# Altium schematic sheet MAIN.SchDoc
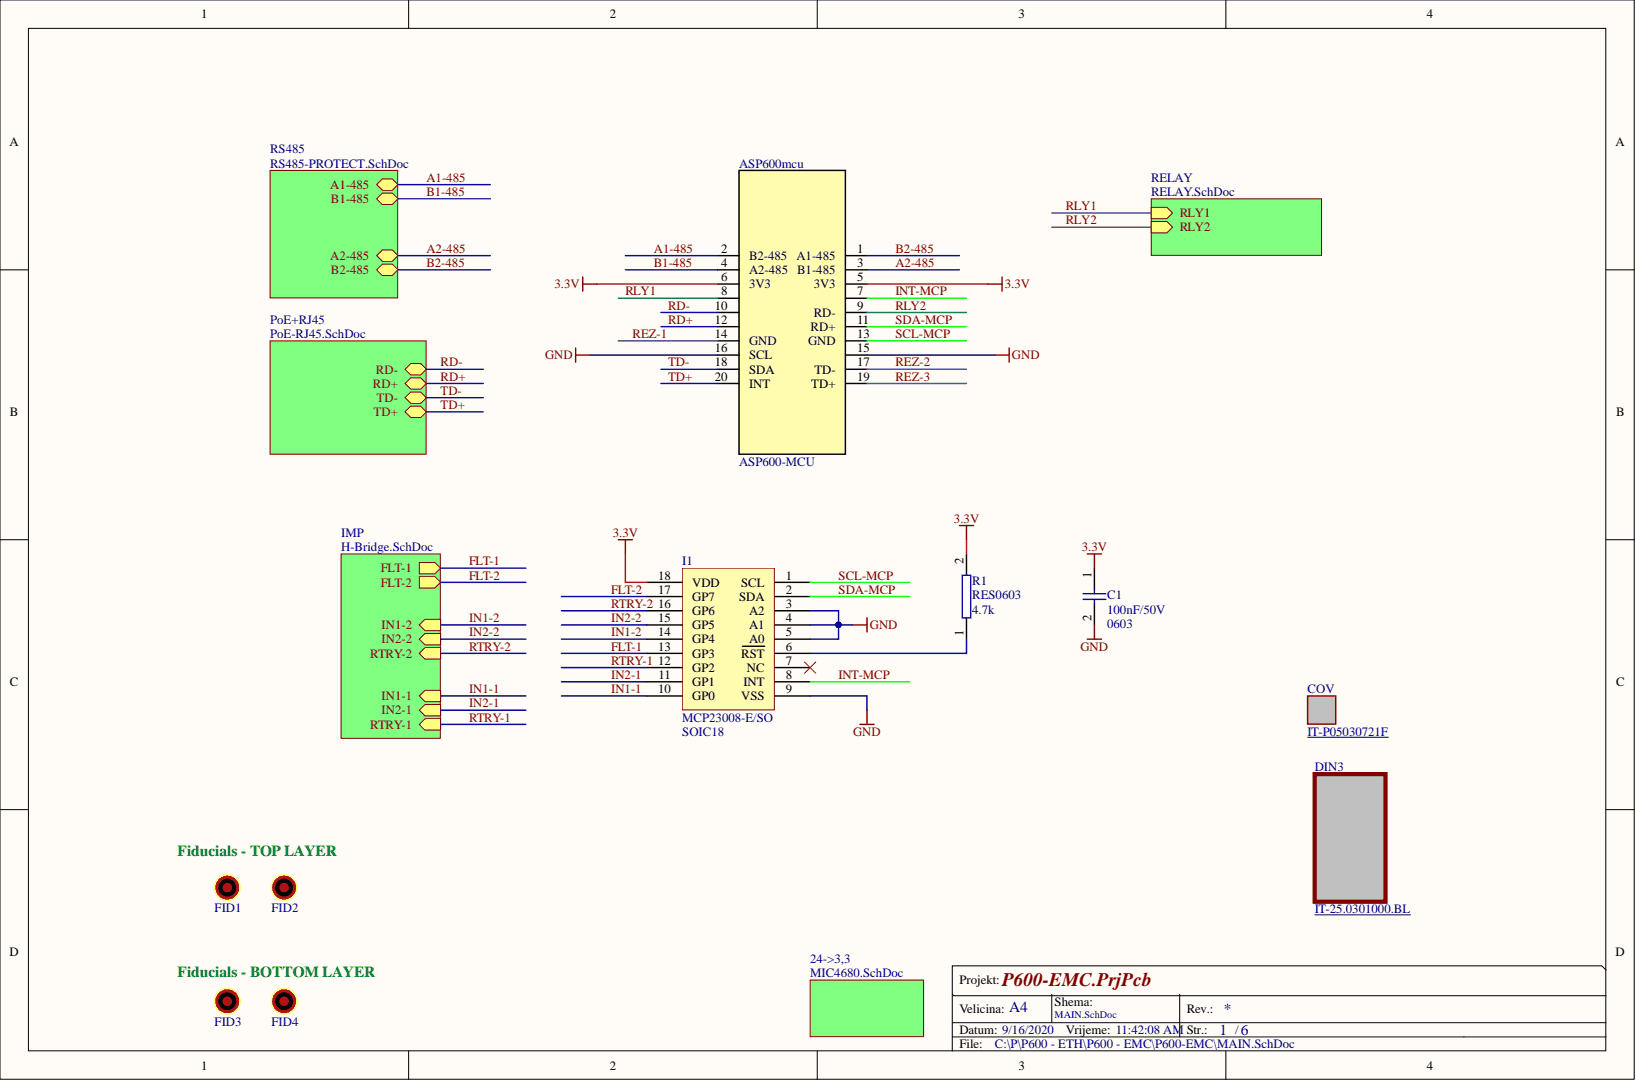
[diagram p0: MAIN.SchDoc]

## Parts
ASP600mcu  Value  [P600-MCU TOP]  (value not drawn)
C1  100nF/50V  Package: 0603  [cap0603]
COV  Supplier Part Number 2: IT-P05030721F  (hidden comment: Value)  (mechanical)
DIN3  Package: DIN3  Supplier Part Number 2: IT-25.0301000.BL  [3-MH]  (hidden comment: Value)
FID1  FID  [FUD]  (no BOM)
FID2  FID  [FUD]  (no BOM)
FID3  FID  [FUD]  (no BOM)
FID4  FID  [FUD]  (no BOM)
I1  MCP23008-E/SO  Package: SOIC18  [SOIC18N]
R1  4.7k  [res0603]

## Nets
3.3V: ASP600mcu.5(3V3) ASP600mcu.6(3V3) C1.1 I1.18(VDD) R1.2
GND: ASP600mcu.15 ASP600mcu.16(SCL) C1.2 I1.3(A2) I1.4(A1) I1.5(A0) I1.9(VSS)
A1-485: ASP600mcu.2(B2-485)
A2-485: ASP600mcu.3(B1-485)
B1-485: ASP600mcu.4(A2-485)
B2-485: ASP600mcu.1(A1-485)
FLT-1: I1.13(GP3)
FLT-2: I1.17(GP7)
IN1-1: I1.10(GP0)
IN1-2: I1.14(GP4)
IN2-1: I1.11(GP1)
IN2-2: I1.15(GP5)
INT-MCP: ASP600mcu.7 I1.8(INT)
RD+: ASP600mcu.12
RD-: ASP600mcu.10
REZ-1: ASP600mcu.14(GND)
REZ-2: ASP600mcu.17(TD-)
REZ-3: ASP600mcu.19(TD+)
RLY1: ASP600mcu.8
RLY2: ASP600mcu.9(RD-)
RTRY-1: I1.12(GP2)
RTRY-2: I1.16(GP6)
SCL-MCP: ASP600mcu.13(GND) I1.1(SCL)
SDA-MCP: ASP600mcu.11(RD+) I1.2(SDA)
TD+: ASP600mcu.20(INT)
TD-: ASP600mcu.18(SDA)
NetI1_6: I1.6(RST) R1.1
NetI1_7: I1.7(NC)

## Library data of the parts
ASP600mcu = PCB_ASP600-MCU: ASP600-MCU; Dobavljač: ELAK; Location: 100-18; Component Kind: Standard; Library Name: ITR000.SchLib; Package: PCB; Supplier 2: ASP600-MCU; Šifra: ASP600-MCU
C1 = CAP_100nF_50V_0603: CAP 100nF 50V 0603; Dobavljač: Farnell; Location: OR1B46; Šifra: 1759122; Component Kind: Standard; LCSC Part #: C24452; Library Name: ITR000.SchLib; Supplier 1: Farnell; Supplier 2: TME; Supplier 3: JLC PCB; Supplier Part Number 1: 1759122; Supplier Part Number 2: CL10B104JB8NNNC; Supplier Part Number 3: CL10B104JB8NNNC; Value: 100nF/50V
COV = 3MH-Cover: 2MH DIN PLAYER; Dobavljač: TME; Location: 100D; Library Name: ITR000.SchLib; Package: Cover; Supplier 2: TME; Supplier 3: JLC PCB; Šifra: IT-P05030721F
DIN3 = 3MH-Enclosure: 3MH DIN PLAYER; Dobavljač: TME; Location: 100D; Šifra: 25.0301000.BL; Component Kind: Standard; Library Name: ITR000.SchLib; Supplier 2: TME; Supplier 3: JLC PCB
FID1-FID4 = FIDUCIAL_POINT: Description: FIDUCIAL_POINT; Library Name: ITR000.SchLib; Package: FIDUCIAL; Value: FID
I1 = IC_MCP23008: MCP23008-E/SO - 8BIT EXPANDER, I/O, I2C I/F, SMD; Dobavljač: Farnell; Location: 100TOP; Šifra: 1332086; Component Kind: Standard; DataSheet: http://www.farnell.com/datasheets/82118.pdf; LCSC Part #: C92253; Library Name: ITR000.SchLib; Supplier 1: Farnell; Supplier 2: TME; Supplier 3: JLC PCB; Supplier Part Number 1: 1332086; Supplier Part Number 2: MCP23008-E/SO; Supplier Part Number 3: MCP23008-E/SO; Value: MCP23008-E/SO
R1 = RES_4.7k_0603: RES  4.7k 0603; Dobavljač: Farnell; Location: OR2E46; Šifra: 2059619; Component Kind: Standard; DataSheet: http://www.vishay.com/docs/20035/dcrcwe3.pdf; LCSC Part #: C23162; Library Name: ITR000.SchLib; Package: RES0603; Supplier 1: Farnell; Supplier 2: TME; Supplier 3: JLC PCB; Supplier Part Number 1: 2059619; Supplier Part Number 2: SMD0603-4K7-1%; Supplier Part Number 3: 0603WAF4701T5E; Value: 4.7k
